# Altium schematic sheet 07_ATK_DLRK3506J_DEVICE.SchDoc
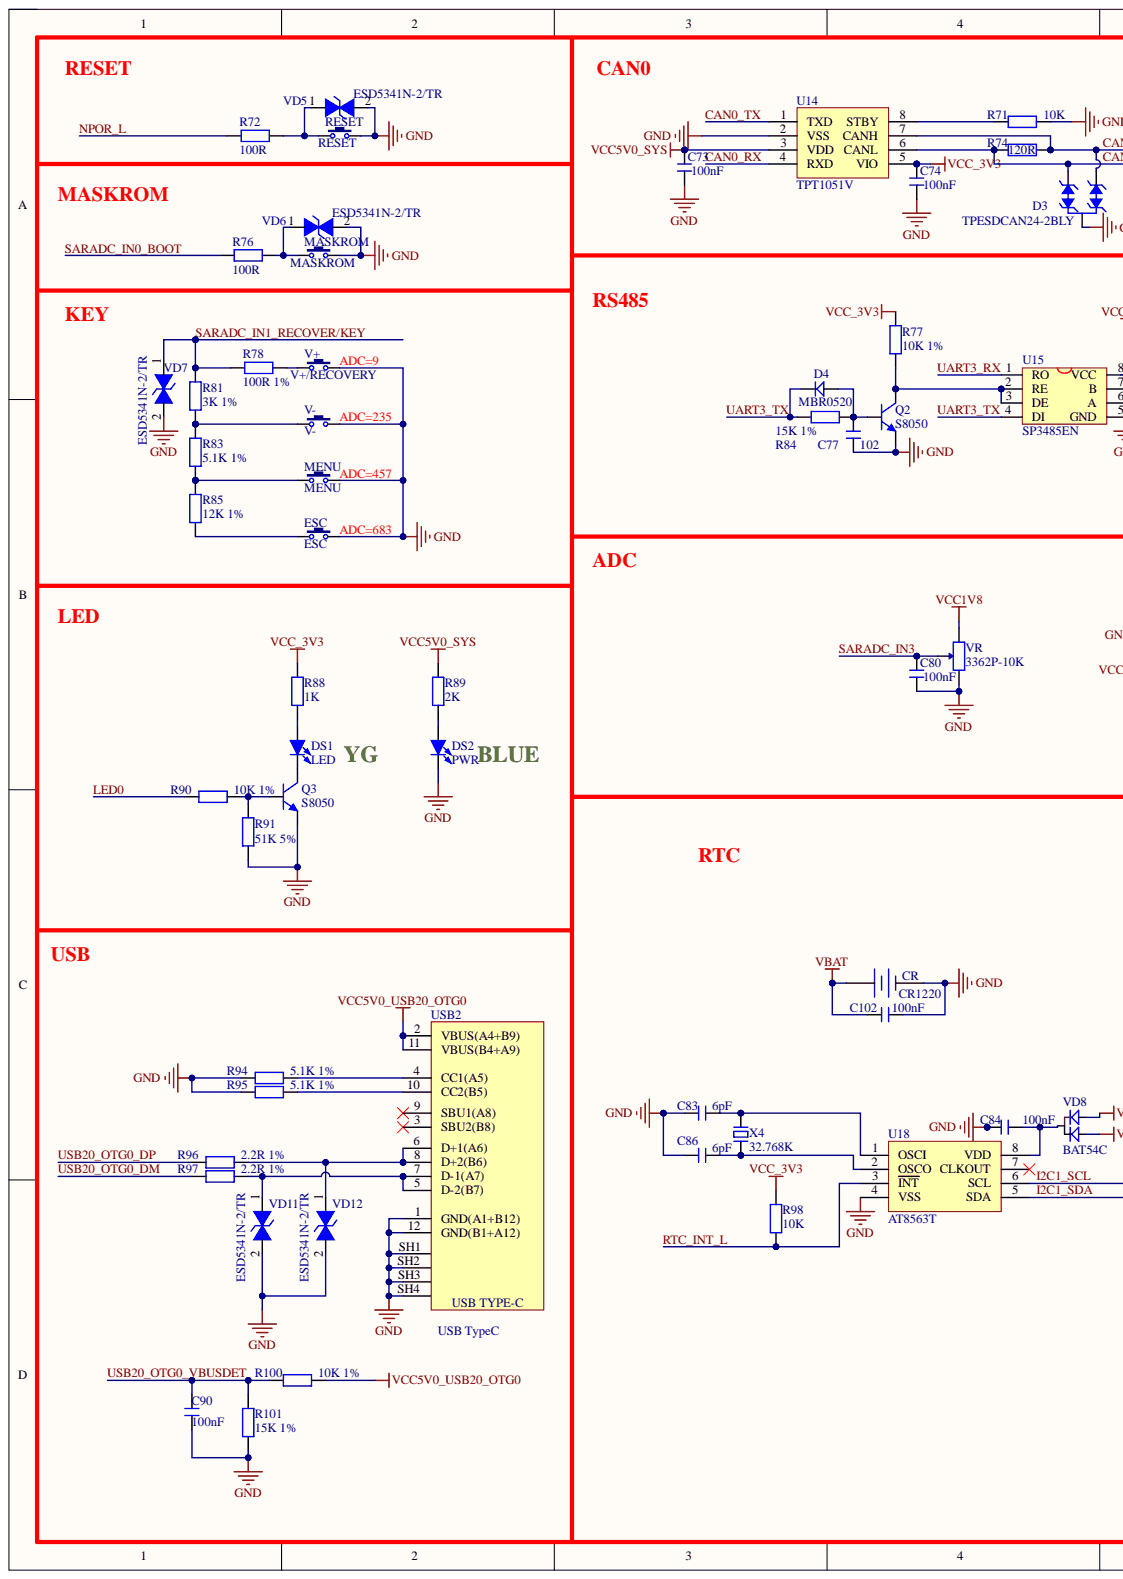
[diagram p0: 07_ATK_DLRK3506J_DEVICE.SchDoc, left]
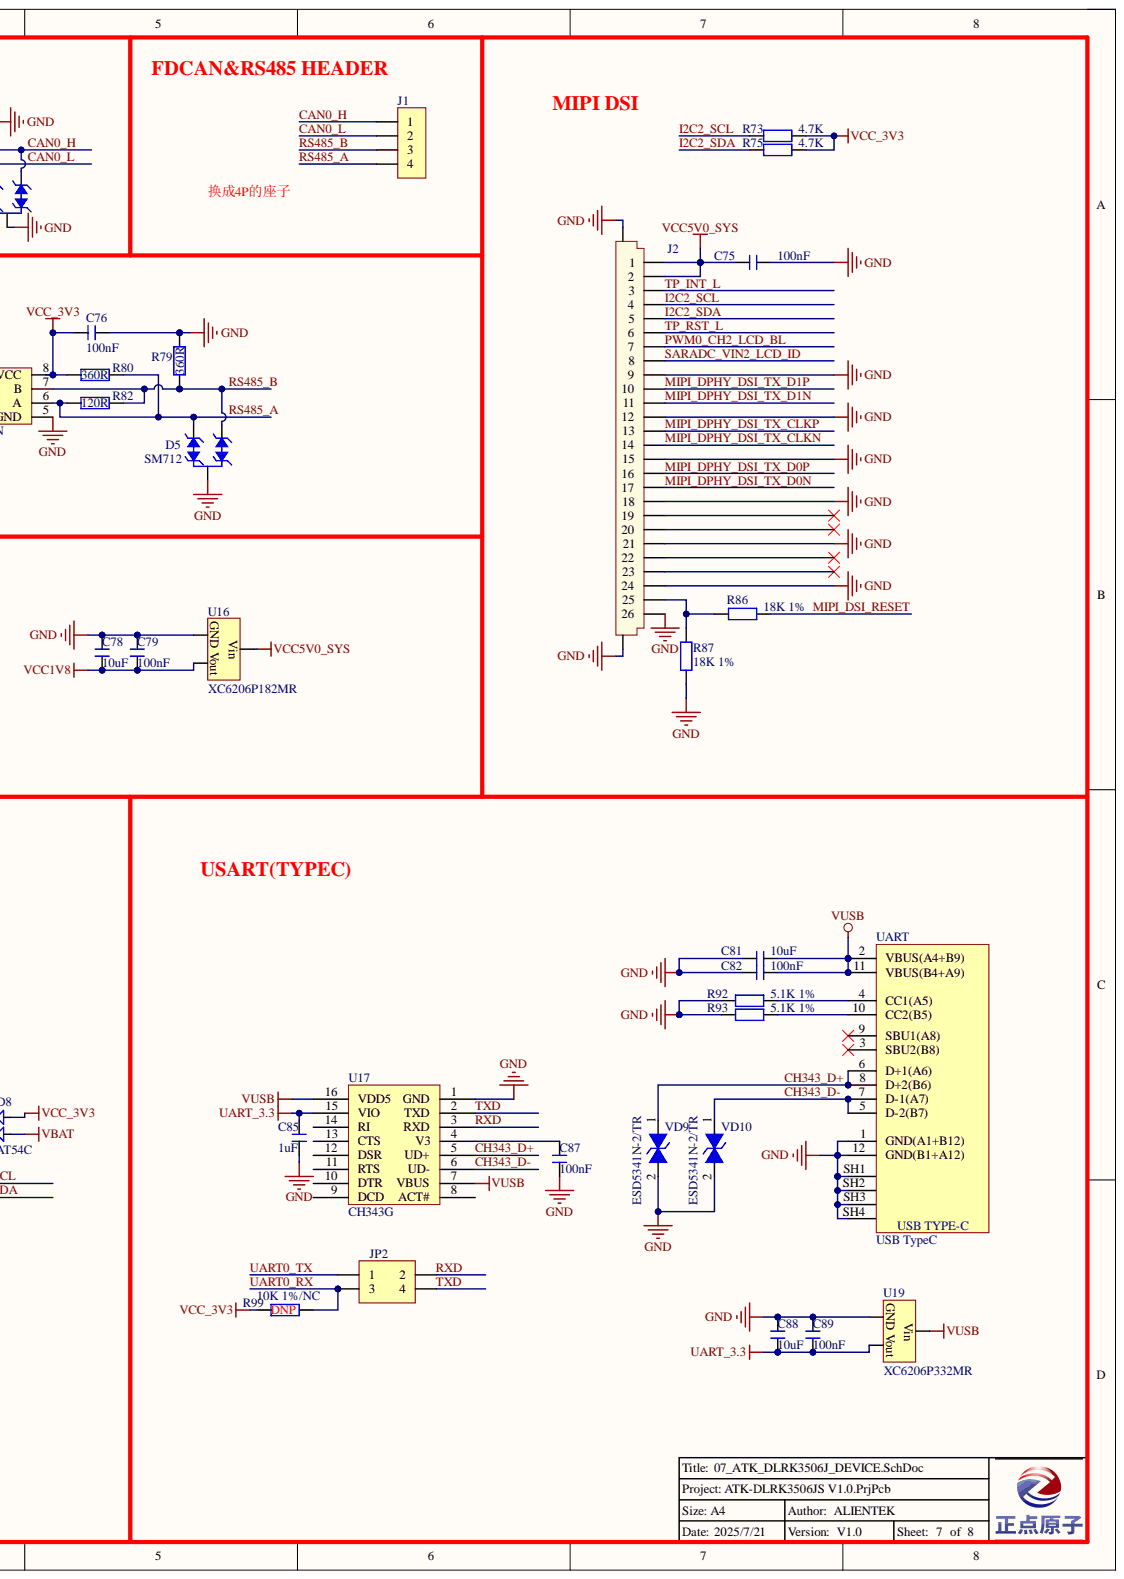
[diagram p1: 07_ATK_DLRK3506J_DEVICE.SchDoc, right]

## Parts
C73  100nF  [C 0402]
C74  100nF  [C 0402]
C75  100nF  [C 0402]
C76  100nF  [C 0402]
C77  102  [C 0402]
C78  10uF  [C 0402]
C79  100nF  [C 0402]
C80  100nF  [C 0402]
C81  10uF  [C 0402]
C82  100nF  [C 0402]
C83  6pF  [C 0402]
C84  100nF  [C 0402]
C85  1uF  [C 0402]
C86  6pF  [C 0402]
C87  100nF  [C 0402]
C88  10uF  [C 0402]
C89  100nF  [C 0402]
C90  100nF  [C 0402]
C102  100nF  [C 0402]
CR  CR1220  [CR1220_A]
D3  TPESDCAN24-2BLY  [SOT23-3L]
D4  MBR0520  [SOD-123F]
D5  SM712  [SOT23-3L]
DS1  LED  [LED 0805G]
DS2  PWR  [LED 0805B]
ESC  ESC  [KEY_SOP324225]
J1  KF2EDGR-3.81-4P  [KF2EDGR_3.81_4P]  (value not drawn)
J2  FPC0.5-26P  [FPC 0.5 26P V-S]  (value not drawn)
JP2  Header2X2  [HDR-2X2]  (value not drawn)
MASKROM  MASKROM  [KEY_SOP324225]
MENU  MENU  [KEY_SOP324225]
Q2  S8050  [SOT23-3N]
Q3  S8050  [SOT23-3N]
R71  10K  [R 0402]
R72  100R  [R 0402]
R73  4.7K  [R 0402]
R74  120R  [R 0603]
R75  4.7K  [R 0402]
R76  100R  [R 0402]
R77  10K 1%  [R 0402]
R78  100R 1%  [R 0402]
R79  360R  [R 0603]
R80  360R  [R 0603]
R81  3K 1%  [R 0402]
R82  120R  [R 0603]
R83  5.1K 1%  [R 0402]
R84  15K 1%  [R 0402]
R85  12K 1%  [R 0402]
R86  18K 1%  [R 0402]
R87  18K 1%  [R 0402]
R88  1K  [R 0402]
R89  2K  [R 0402]
R90  10K 1%  [R 0402]
R91  51K 5%  [R 0402]
R92  5.1K 1%  [R 0402]
R93  5.1K 1%  [R 0402]
R94  5.1K 1%  [R 0402]
R95  5.1K 1%  [R 0402]
R96  2.2R 1%  [R 0402]
R97  2.2R 1%  [R 0402]
R98  10K  [R 0402]
R99  10K 1%/NC  [R 0402]
R100  10K 1%  [R 0402]
R101  15K 1%  [R 0402]
RESET  RESET  [KEY_SOP324225]
U14  TPT1051V  [SOP8_N]
U15  SP3485EN  [SOP8_N]
U16  XC6206P182MR  [SOT23-3L]
U17  CH343G  [SOP16_M]
U18  AT8563T  [SOP8_N]
U19  XC6206P332MR  [SOT23-3L]
UART  USB TypeC  [USB2.0-TYPE-C-16P]
USB2  USB TypeC  [USB2.0-TYPE-C-16P]
V+  V+/RECOVERY  [KEY_SOP324225]
V-  V-  [KEY_SOP324225]
VD5  ESD5341N-2/TR  [ESD0402]
VD6  ESD5341N-2/TR  [ESD0402]
VD7  ESD5341N-2/TR  [ESD0402]
VD8  BAT54C  [SOT23-3N]
VD9  ESD5341N-2/TR  [ESD0402]
VD10  ESD5341N-2/TR  [ESD0402]
VD11  ESD5341N-2/TR  [ESD0402]
VD12  ESD5341N-2/TR  [ESD0402]
VR  3362P-10K  [3362P]
X4  32.768K  [XTAL-2X6H]

## Nets
GND: C73.2 C74.2 C75.2 C76.2 C77.2 C78.1 C79.1 C80.2 C81.1 C82.1 C83.1 C84.1 C85.2 C86.1 C87.2 C88.1 C89.1 C90.2 C102.1 CR.2 D3.3 D5.3 DS2.2 ESC.2 J2.0 J2.9 J2.12 J2.15 J2.18 J2.21 J2.24 J2.26 MASKROM.2 MENU.2 Q2.2 Q3.2 R71.2 R79.1 R87.2 R91.1 R92.1 R93.1 R94.1 R95.1 R101.1 RESET.2 U14.2(VSS) U15.5(GND) U16.1 U17.1(GND) U18.4(VSS) U19.1 UART.1(GND(A1+B12)) UART.12(GND(B1+A12)) UART.SH1(Shield1) UART.SH2(Shield2) UART.SH3(Shield3) UART.SH4(Shield4) USB2.1(GND(A1+B12)) USB2.12(GND(B1+A12)) USB2.SH1(Shield1) USB2.SH2(Shield2) USB2.SH3(Shield3) USB2.SH4(Shield4) V+.2 V-.2 VD5.2 VD6.2 VD7.2 VD9.2 VD10.2 VD11.2 VD12.2 VR.1
UART_3.3: C85.1 C88.2 C89.2 U17.15(VIO) U19.2
VBAT: C102.2 CR.1 VD8.1
VCC1V8: C78.2 C79.2 U16.2 VR.3
VCC5V0_SYS: C73.1 C75.1 J2.1 J2.2 R89.2 U14.3(VDD) U16.3
VCC5V0_USB20_OTG0: R100.2 USB2.2(VBUS(A4+B9)) USB2.11(VBUS(B4+A9))
VCC_3V3: C74.1 C76.1 R73.2 R75.2 R77.2 R80.2 R88.2 R98.2 R99.1 U14.5(VIO) U15.8(VCC) VD8.2
VUSB: C81.2 C82.2 U17.7(VBUS) U17.16(VDD5) U19.3 UART.2(VBUS(A4+B9)) UART.11(VBUS(B4+A9))
CAN0_H: D3.1 J1.1 R74.2 U14.7(CANH)
CAN0_L: D3.2 J1.2 R74.1 U14.6(CANL)
CAN0_RX: U14.4(RXD)
CAN0_TX: U14.1(TXD)
CH343_D+: U17.5(UD+) UART.6(D+1(A6)) UART.8(D+2(B6)) VD9.1
CH343_D-: U17.6(UD-) UART.5(D-2(B7)) UART.7(D-1(A7)) VD10.1
I2C1_SCL: U18.6(SCL)
I2C1_SDA: U18.5(SDA)
I2C2_SCL: J2.4 R73.1
I2C2_SDA: J2.5 R75.1
LED0: R90.1
MIPI_DPHY_DSI_TX_CLKN: J2.14
MIPI_DPHY_DSI_TX_CLKP: J2.13
MIPI_DPHY_DSI_TX_D0N: J2.17
MIPI_DPHY_DSI_TX_D0P: J2.16
MIPI_DPHY_DSI_TX_D1N: J2.11
MIPI_DPHY_DSI_TX_D1P: J2.10
MIPI_DSI_RESET: R86.1
NPOR_L: R72.2
PWM0_CH2_LCD_BL: J2.7
RS485_A: D5.2 J1.4 R80.1 R82.2 U15.6(A)
RS485_B: D5.1 J1.3 R79.2 R82.1 U15.7(B)
RTC_INT_L: R98.1 U18.3(INT)
RXD: JP2.2 U17.3(RXD)
SARADC_IN0_BOOT: R76.2
SARADC_IN1_RECOVER/KEY: R78.2 R81.1 VD7.1
SARADC_IN3: C80.1 VR.2
SARADC_VIN2_LCD_ID: J2.8
TP_INT_L: J2.3
TP_RST_L: J2.6
TXD: JP2.4 U17.2(TXD)
UART0_RX: JP2.3 R99.2
UART0_TX: JP2.1
UART3_RX: U15.1(RO)
UART3_TX: D4.2 R84.2 U15.4(DI)
USB20_OTG0_DM: R97.1
USB20_OTG0_DP: R96.1
USB20_OTG0_VBUSDET: C90.1 R100.1 R101.2
NetC77_1: C77.1 D4.1 Q2.1 R84.1
NetC83_2: C83.2 U18.1(OSCI) X4.1
NetC84_2: C84.2 U18.8(VDD) VD8.3
NetC86_2: C86.2 U18.2(OSCO) X4.2
NetC87_1: C87.1 U17.4(V3)
NetDS1_1: DS1.1 R88.1
NetDS1_2: DS1.2 Q3.3
NetDS2_1: DS2.1 R89.1
NetESC_1: ESC.1 R85.2
NetJ2_25: J2.25 R86.2 R87.1
NetMASKROM_1: MASKROM.1 R76.1 VD6.1
NetMENU_1: MENU.1 R83.2 R85.1
NetQ2_3: Q2.3 R77.1 U15.2(RE) U15.3(DE)
NetQ3_1: Q3.1 R90.2 R91.2
NetR71_1: R71.1 U14.8(STBY)
NetR72_1: R72.1 RESET.1 VD5.1
NetR78_1: R78.1 V+.1
NetR81_2: R81.2 R83.1 V-.1
NetR92_2: R92.2 UART.4(CC1(A5))
NetR93_2: R93.2 UART.10(CC2(B5))
NetR94_2: R94.2 USB2.4(CC1(A5))
NetR95_2: R95.2 USB2.10(CC2(B5))
NetR96_2: R96.2 USB2.6(D+1(A6)) USB2.8(D+2(B6)) VD12.1
NetR97_2: R97.2 USB2.5(D-2(B7)) USB2.7(D-1(A7)) VD11.1

Not connected: J2 19 20 22 23; U17 8(ACT#) 9(DCD) 10(DTR) 11(RTS) 12(DSR) 13(CTS) 14(RI); U18 7(CLKOUT); UART 3(SBU2(B8)) 9(SBU1(A8)); USB2 3(SBU2(B8)) 9(SBU1(A8))

## Library data of the parts
C73 C74 C76 C80 C85 = C: 无极性贴片电容; 版权归属: 源创客; BOM名称: 无极性贴片电容; Component Kind: Standard; Library Name: 电阻-电容-电感.SchLib; 学习资源: www.ooocke.com
C75 C78 C79 C81 C82 C84 C87-C90 = C: 无极性电容; BOM名称: 无极性贴片电容; 版权归属: 正点原子; Component Kind: Standard; Library Name: 正点原子封装库V1.0.IntLib; 学习资源: www.openedv.com
C77 C83 C86 C102 = C: 无极性贴片电容; 版权归属: 正点原子; BOM名称: 无极性贴片电容; Component Kind: Standard; 学习资源: www.openedv.com
  C102: Library Name: 电阻-电容-电感.SchLib
  C77 C83 C86: Library Name: Lycreturn.IntLib
CR = CR-3V: 微型电池; 版权归属: 正点原子; BOM名称: 微型电池; Component Kind: Standard; Library Name: 未分类器件.SchLib; 学习资源: www.openedv.com
D3 D5 = HDW24M2T-B: ESD; Component Kind: Standard; Library Name: ATK-DLMP257_V1.0.SchLib
D4 = SS14: 肖特基二极管; 属性: 40V/1A; 版权归属: 源创客; BOM名称: 肖特基二极管; Component Kind: Standard; Library Name: STM32MP15x底板V1.5.IntLib; 学习资源: www.ooocke.com
DS1 = LED-SMD: 贴片黄绿LED; BOM名称: 贴片LED; Parameter: 发光二极管; 版权归属: 正点原子; Component Kind: Standard; 学习资源: www.openedv.com; Library Name: Lycreturn.IntLib
DS2 = LED-SMD: 贴片LED; Parameter: 发光二极管; 版权归属: 正点原子; BOM名称: 贴片LED; Component Kind: Standard; 学习资源: www.openedv.com; Library Name: Lycreturn.IntLib
ESC MASKROM MENU RESET V+ V- = KEY: 按键; BOM名称: 3x6轻触开关; 版权归属: 正点原子; Component Kind: Standard; 学习资源: www.openedv.com; Library Name: Lycreturn.IntLib
J1 = Header 4: 4P接插件; Parameter: 接插件; 版权归属: 正点原子; BOM名称: 4P接插件; Component Kind: Standard; Library Name: 正点原子封装库V1.0.IntLib; 学习资源: www.openedv.com
J2 = FPC-26P: FPC连接器; Parameter: 接口器件; 版权归属: 正点原子; BOM名称: FPC连接器; Component Kind: Standard; Library Name: 正点原子封装库V1.0.IntLib; 学习资源: www.openedv.com
JP2 = Header 2X2: 2*2P接插件; 版权归属: 源创客; BOM名称: 2*2P接插件; Component Kind: Standard; 学习资源: www.ooocke.com; Library Name: Lycreturn.IntLib
Q2 = 8050-SMD: 高频放大-NPN型; 属性: 40V 1.5A 1W 100MHZ; 版权归属: 正点原子; BOM名称: 高频放大-NPN型; Component Kind: Standard; 学习资源: www.openedv.com; Library Name: Lycreturn.IntLib
Q3 = 8050-SMD: 高频放大-NPN型; Parameter: 三极管; 属性: 40V 1.5A 1W 100MHZ; 版权归属: 正点原子; BOM名称: 高频放大-NPN型; Component Kind: Standard; 学习资源: www.ooocke.com; Library Name: Lycreturn.IntLib
R71-R76 R78 R81 R83 R85-R101 = R: 贴片电阻; BOM名称: 电阻; 版权归属: 正点原子; Component Kind: Standard; Library Name: 电阻-电容-电感.SchLib; 学习资源: www.openedv.com
R77 R79 R80 R82 R84 = R: 贴片电阻; 版权归属: 正点原子; BOM名称: 贴片电阻; Component Kind: Standard; 学习资源: www.openedv.com; Library Name: Lycreturn.IntLib
U14 = MCP2562FDT: Component Kind: Standard; Library Name: Lycreturn.IntLib
U15 = MAX3485: Component Kind: Standard; Library Name: Lycreturn.IntLib
U16 U19 = XC6206: LDO 5.5V 200-300mA; Parameter: 芯片; 版权归属: 正点原子; Component Kind: Standard; Package: SOT-23; 学习资源: www.openedv.com; Library Name: Lycreturn.IntLib
U18 = PCF8563: Component Kind: Standard; Library Name: ZZK_COMPONENT.IntLib
UART USB2 = USB Type-C: 12P TypeC 母座; Parameter: 接口器件; 版权归属: 正点原子; Component Kind: Standard; 学习资源: www.openedv.com; Library Name: Lycreturn.IntLib
VD5-VD7 VD9-VD12 = ESD5341N: ESD二极管-单向; Component Kind: Standard; Library Name: ZZK_COMPONENT.IntLib
VD8 = BAT54C: 肖特基二极管（双）; Component Kind: Standard; Library Name: 二极管-整流桥.SchLib
VR = RP-ID: 插件单联电位器; BOM名称: 插件电位器; 版权归属: 正点原子; Component Kind: Standard; 学习资源: www.openedv.com; Library Name: Lycreturn.IntLib
X4 = XTAL-2P: 2脚无源晶振; BOM名称: 2脚晶振; 版权归属: 正点原子; Component Kind: Standard; 学习资源: www.openedv.com; Library Name: Lycreturn.IntLib
U17 = CH343G: Component Kind: Standard; Library Name: ZZK_COMPONENT.IntLib
